# Altium schematic sheet Connectors.SchDoc
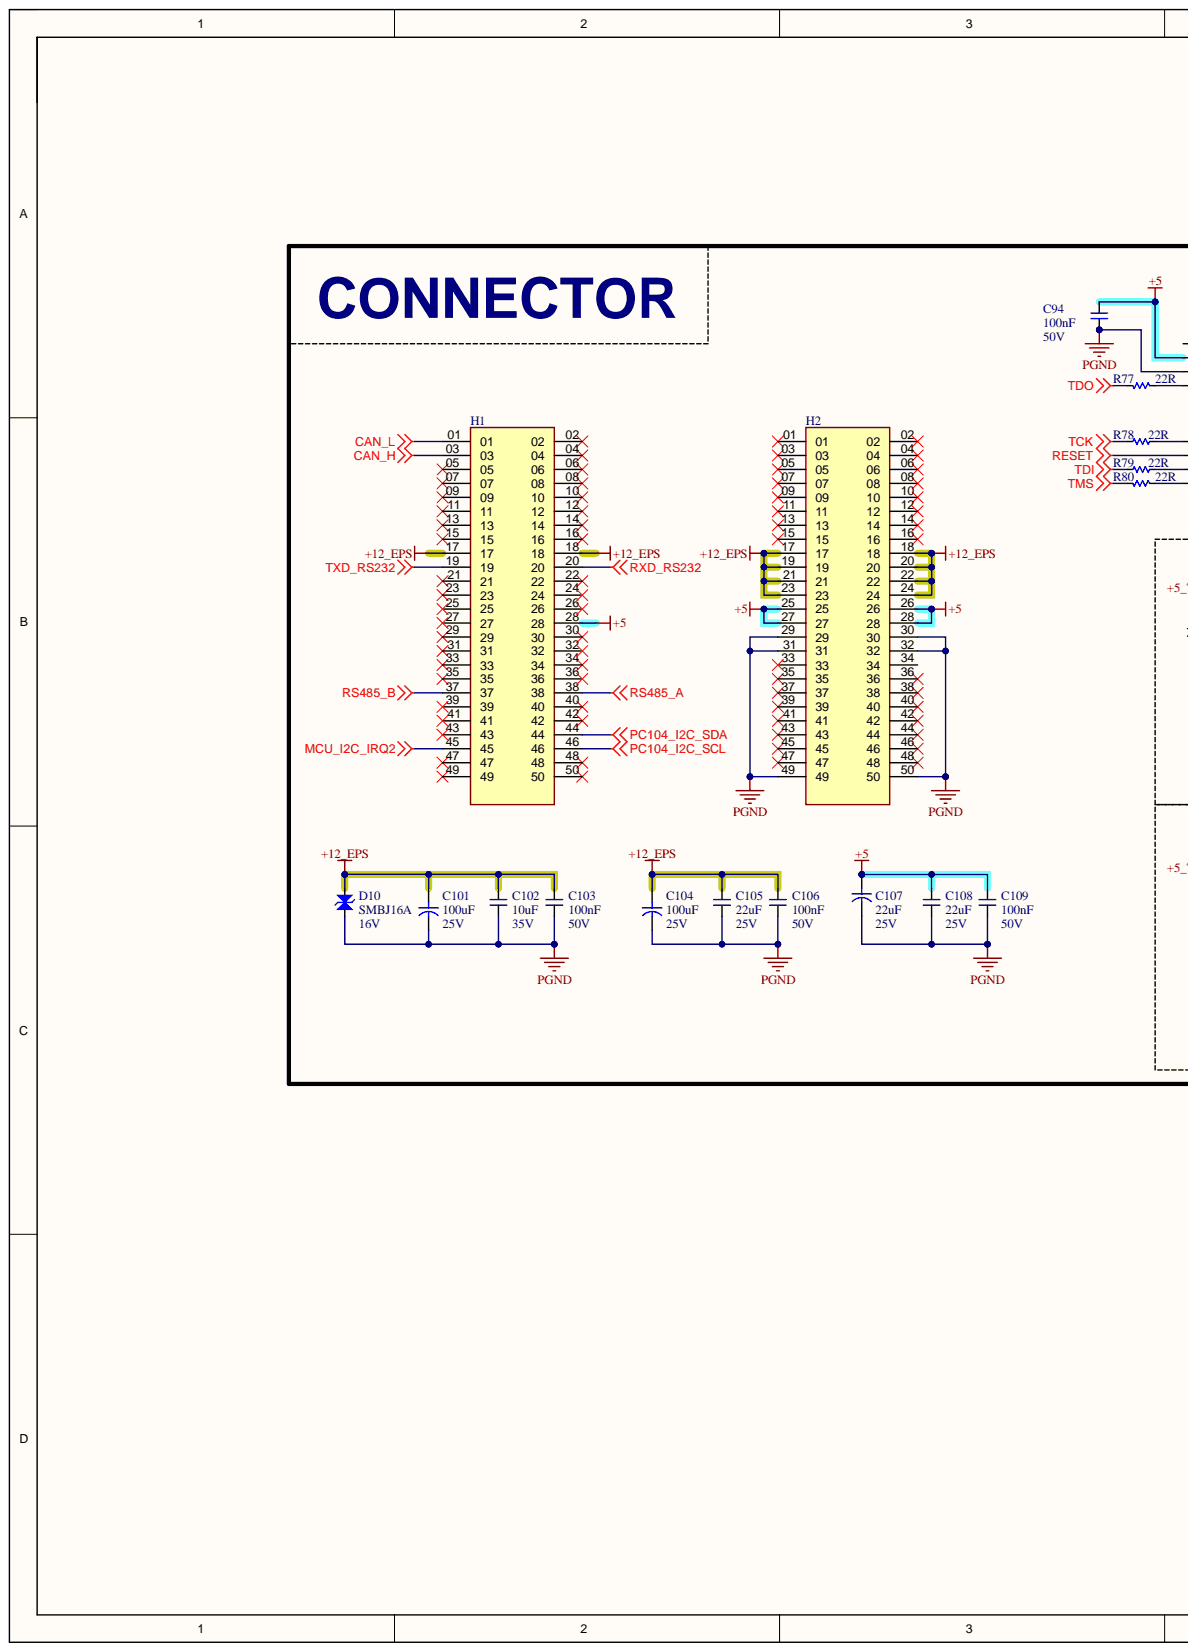
[diagram p0: Connectors.SchDoc, left]
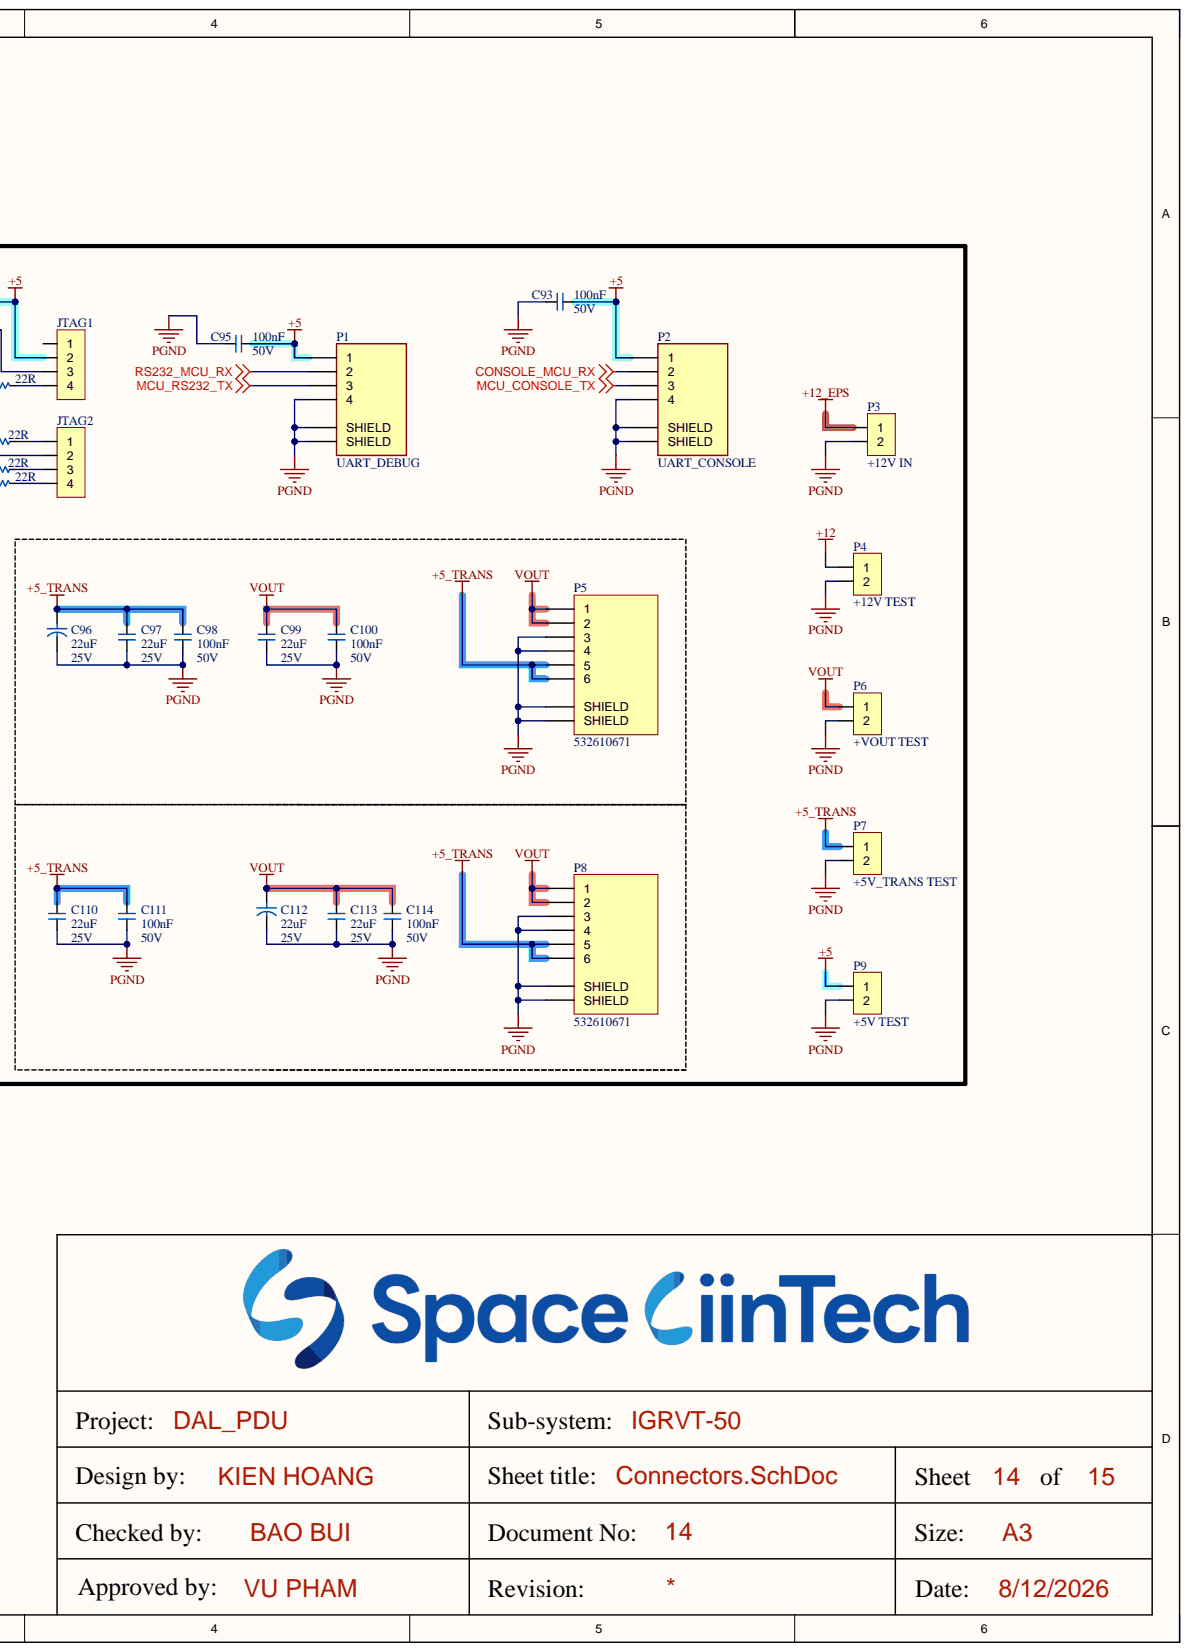
[diagram p1: Connectors.SchDoc, right]

## Parts
C93  100nF  Voltage: 50V  [CAP_C_1608X80]
C94  100nF  Voltage: 50V  [CAP_C_1608X80]
C95  100nF  Voltage: 50V  [CAP_C_1608X80]
C96  22uF  Voltage: 25V  [C SMD TAN 2312 (C)]
C97  22uF  Voltage: 25V  [CAP_C_2012X110]
C98  100nF  Voltage: 50V  [CAP_C_1608X80]
C99  22uF  Voltage: 25V  [CAP_C_2012X110]
C100  100nF  Voltage: 50V  [CAP_C_1608X80]
C101  100uF  Voltage: 25V  [C SMD TAN 2917 (E)]
C102  10uF  Voltage: 35V  [CAP_C_2012X110]
C103  100nF  Voltage: 50V  [CAP_C_1608X80]
C104  100uF  Voltage: 25V  [C SMD TAN 2917 (E)]
C105  22uF  Voltage: 25V  [CAP_C_2012X110]
C106  100nF  Voltage: 50V  [CAP_C_1608X80]
C107  22uF  Voltage: 25V  [C SMD TAN 2312 (C)]
C108  22uF  Voltage: 25V  [CAP_C_2012X110]
C109  100nF  Voltage: 50V  [CAP_C_1608X80]
C110  22uF  Voltage: 25V  [CAP_C_2012X110]
C111  100nF  Voltage: 50V  [CAP_C_1608X80]
C112  22uF  Voltage: 25V  [C SMD TAN 2312 (C)]
C113  22uF  Voltage: 25V  [CAP_C_2012X110]
C114  100nF  Voltage: 50V  [CAP_C_1608X80]
D10  SMBJ16A  Voltage: 16V  [DO-214AA_SMB]
H1  ESQ-126-14-G-D  [ESQ-126-14-G-D]  (value not drawn)
H2  ESQ-126-14-G-D  [ESQ-126-14-G-D]  (value not drawn)
JTAG1  Header 4  [HDR/Header/4/2mm]  (value not drawn)
JTAG2  Header 4  [HDR/Header/4/2mm]  (value not drawn)
P1  UART_DEBUG  [0532610471]
P2  UART_CONSOLE  [0532610471]
P3  +12V IN  [HDR/Header/2/BOX/No STEP]
P4  +12V TEST  [HDR/Header/2/BOX/No STEP]
P5  532610671  [532610671]
P6  +VOUT TEST  [HDR/Header/2/BOX/No STEP]
P7  +5V_TRANS TEST  [HDR/Header/2/BOX/No STEP]
P8  532610671  [532610671]
P9  +5V TEST  [HDR/Header/2/BOX/No STEP]
R77  22R  [RES_C_1005X40]
R78  22R  [RES_C_1005X40]
R79  22R  [RES_C_1005X40]
R80  22R  [RES_C_1005X40]

## Nets
+5: C93.1 C94.1 C95.1 C107.1 C108.2 C109.2 H1.28 H2.25 H2.26 H2.27 H2.28 JTAG1.2 P1.1 P2.1 P9.1
+5_TRANS: C96.1 C97.2 C98.2 C110.2 C111.2 P5.5 P5.6 P7.1 P8.5 P8.6
+12: P4.1
+12_EPS: C101.1 C102.2 C103.2 C104.1 C105.2 C106.2 D10.1 H1.17 H1.18 H2.17 H2.18 H2.19 H2.20 H2.21 H2.22 H2.23 H2.24 P3.1
CAN_H: H1.03
CAN_L: H1.01
CONSOLE_MCU_RX: P2.2
MCU_CONSOLE_TX: P2.3
MCU_I2C_IRQ2: H1.45
MCU_RS232_TX: P1.3
PC104_I2C_SCL: H1.46
PC104_I2C_SDA: H1.44
PGND: C93.2 C94.2 C95.2 C96.2 C97.1 C98.1 C99.1 C100.1 C101.2 C102.1 C103.1 C104.2 C105.1 C106.1 C107.2 C108.1 C109.1 C110.1 C111.1 C112.2 C113.1 C114.1 D10.2 H2.29 H2.30 H2.31 H2.32 H2.49 H2.50 JTAG1.3 P1.4 P1.S1(SHIELD) P1.S2(SHIELD) P2.4 P2.S1(SHIELD) P2.S2(SHIELD) P3.2 P4.2 P5.3 P5.4 P5.S1(SHIELD) P5.S2(SHIELD) P6.2 P7.2 P8.3 P8.4 P8.S1(SHIELD) P8.S2(SHIELD) P9.2
RESET: JTAG2.2
RS232_MCU_RX: P1.2
RS485_A: H1.38
RS485_B: H1.37
RXD_RS232: H1.20
TCK: R78.1
TDI: R79.1
TDO: R77.2
TMS: R80.1
TXD_RS232: H1.19
VOUT: C99.2 C100.2 C112.1 C113.2 C114.2 P5.1 P5.2 P6.1 P8.1 P8.2
NetJTAG1_4: JTAG1.4 R77.1
NetJTAG2_1: JTAG2.1 R78.2
NetJTAG2_3: JTAG2.3 R79.2
NetJTAG2_4: JTAG2.4 R80.2

Not connected: H1 02 04 05 06 07 08 09 10 11 12 13 14 15 16 21 22 23 24 25 26 27 29 30 31 32 33 34 35 36 39 40 41 42 43 47 48 49 50; H2 01 02 03 04 05 06 07 08 09 10 11 12 13 14 15 16 33 34 35 36 37 38 39 40 41 42 43 44 45 46 47 48; JTAG1 1

## Library data of the parts
C93-C95 C97-C100 C102 C103 C105 C106 C108-C111 C113 C114 = _CAP_C_2: CAP; Component Kind: Standard; Library Name: LABLIB.SchLib
C96 C101 C104 C107 C112 = Capacitor: Capacitor pol; Component Kind: Standard; Library Name: KIENASIY123_LIB.IntLib
D10 = Diode TVS: TVS Diode; Code - JEDEC: DO-214-AB; Value: 1N5007; Component Kind: Standard; Published: 8-Jun-2000; Revision: July-2002: Re-released for DXP Platform.; Library Name: LABLIB.SchLib; Publisher: Altium Limited
JTAG1 JTAG2 = Header 4: Header, 4-Pin; Component Kind: Standard; Library Name: KIENASIY123_LIB.IntLib
P1 P2 = 0532610471: Component Kind: Standard; Library Name: KIENASIY123_LIB.IntLib
P3 P4 P6 P7 P9 = Header 2: Header, 2-Pin; Component Kind: Standard; Library Name: KIENASIY123_LIB.IntLib
R77-R80 = _RES_B_2_2: RES; Component Kind: Standard; Library Name: LABLIB.SchLib
H1 H2 = ESQ-126-14-G-D: Component Kind: Standard; Library Name: KIENASIY123_LIB.IntLib
P5 P8 = 532610671: Component Kind: Standard; Library Name: connectors.SchLib
